# Altium schematic sheet PULSE_MUX.SchDoc
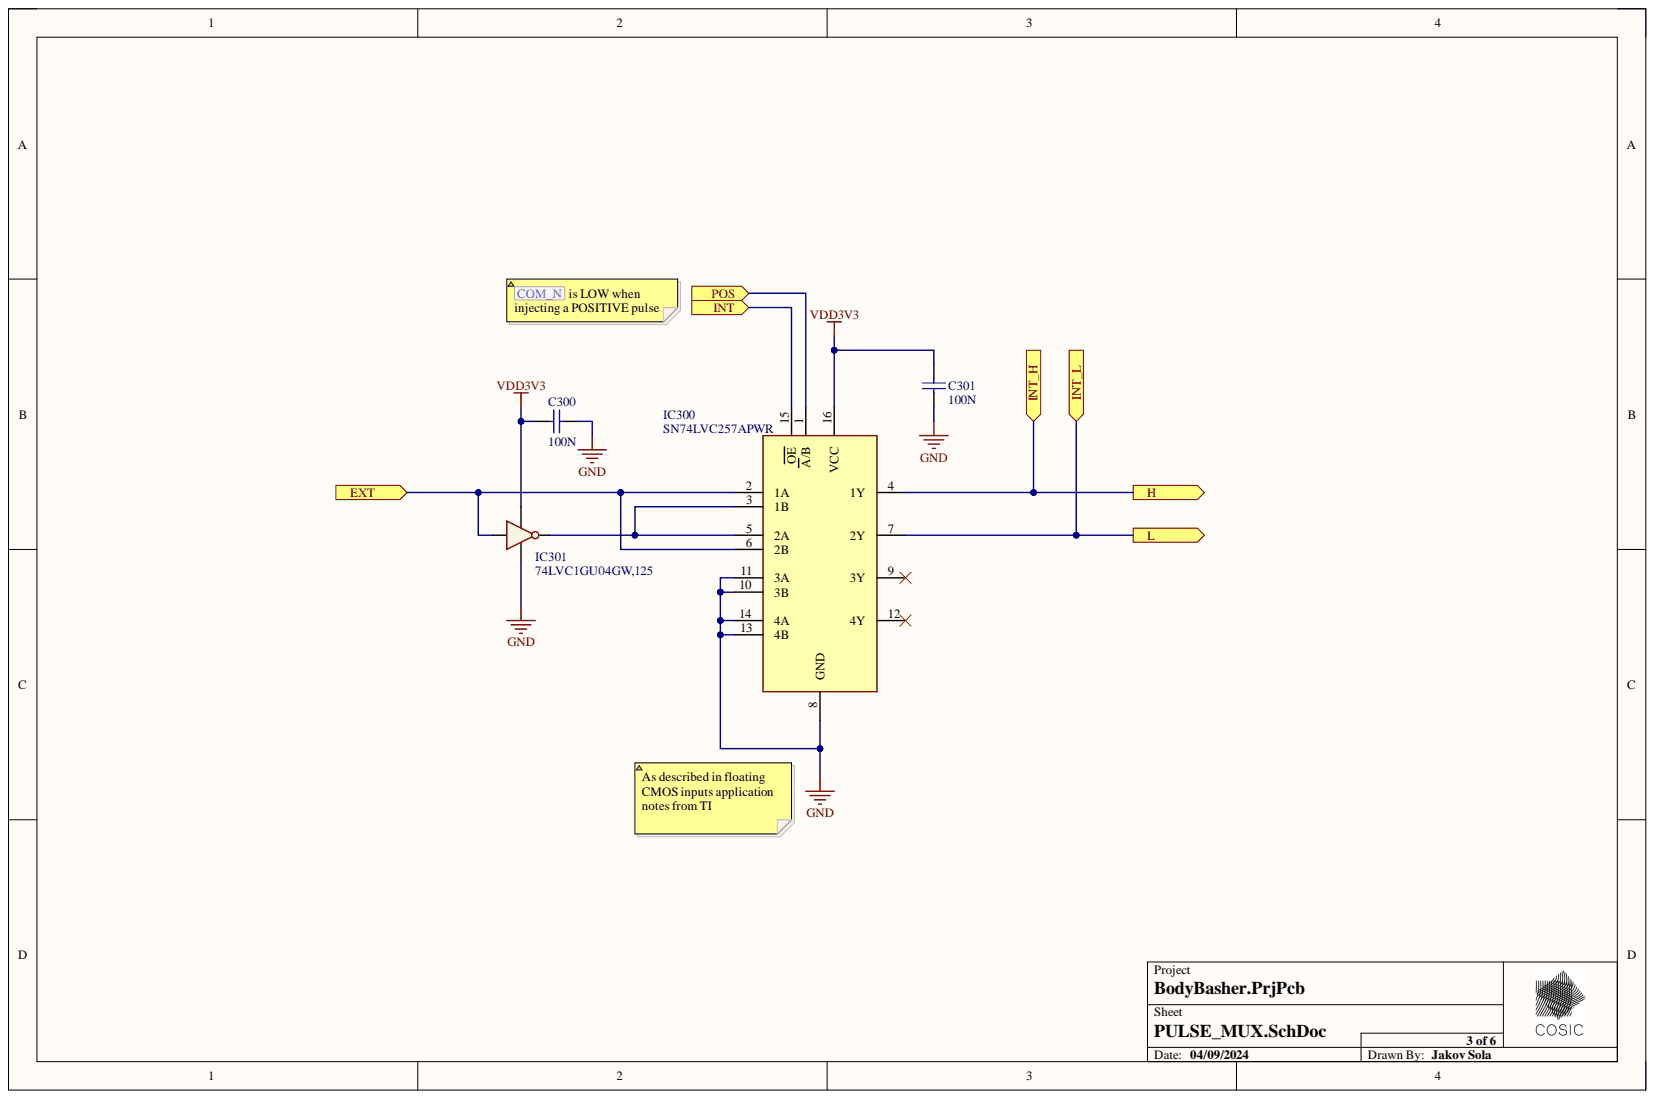
[diagram p0: PULSE_MUX.SchDoc]

## Parts
C300  value 100N  [CAPC0603N]  (hidden comment: Cap)
C301  value 100N  [CAPC0603N]  (hidden comment: Cap)
IC300  SN74LVC257APWR  [SOP65P640X120-16N]
IC301  INV_SINGLE  [SOT65P212X110-5N]  (value not drawn)

## Ports
EXT, H, INT, INT_H, INT_L, L, POS

## Nets
GND: C300.1 C301.1 IC300.8(GND) IC300.10(3B) IC300.11(3A) IC300.13(4B) IC300.14(4A) IC301.3
VDD3V3: C300.2 C301.2 IC300.16(VCC) IC301.5
EXT: IC300.2(1A) IC300.6(2B) IC301.2 ; port EXT
H: IC300.4(1Y) ; port H, port INT_H
INT: IC300.15(OE) ; port INT
INT_L: IC300.7(2Y) ; port INT_L, port L
POS: IC300.1(A/B) ; port POS
NetIC300_3: IC300.3(1B) IC300.5(2A) IC301.4
NetIC300_9: IC300.9(3Y)
NetIC300_12: IC300.12(4Y)

## Library data of the parts
C300 C301 = CL10B104KA8WPNC: MANUF: SAMSUNG; Database Table Name: Fixed_capacitors$; DESCR: CAP 100N 0603 25V X7R 10%; POPULATE: YES; TOLERANCE: 0.1; VENDOR: DIGI-KEY; VENDORCODE: 1276-6717-1-ND; VOLTAGE: 25V; Component Kind: Standard; Library Name: Capacitors.DbLib; MANUFCODE: CL10B104KA8WPNC; PACKAGE: 0603; TECHNOLOGY: X7R; VALUE_4C: 100N
IC300 = SN74LVC257APWR: MANUF: TI; Database Table Name: IC$; DESCR: IC MUX QUAD 2:1 3 STATE; POPULATE: YES; VENDOR: MOUSER; VENDORCODE: 595-SN74LVC257APWR; Component Kind: Standard; Library Name: Integrated_circuits.DbLib; MANUFCODE: SN74LVC257APWR
IC301 = 74LVC1GU04GW,125: MANUF: NEXPERIA; Database Table Name: IC$; DESCR: IC INV SINGLE UNBUFFERED; POPULATE: YES; VENDOR: MOUSER; VENDORCODE: 771-LVC1GU04GW125; Component Kind: Standard; Library Name: Integrated_circuits.DbLib; MANUFCODE: 74LVC1GU04GW,125
